# Altium schematic sheet 05_GAP9_CHIP_GPIO.SchDoc
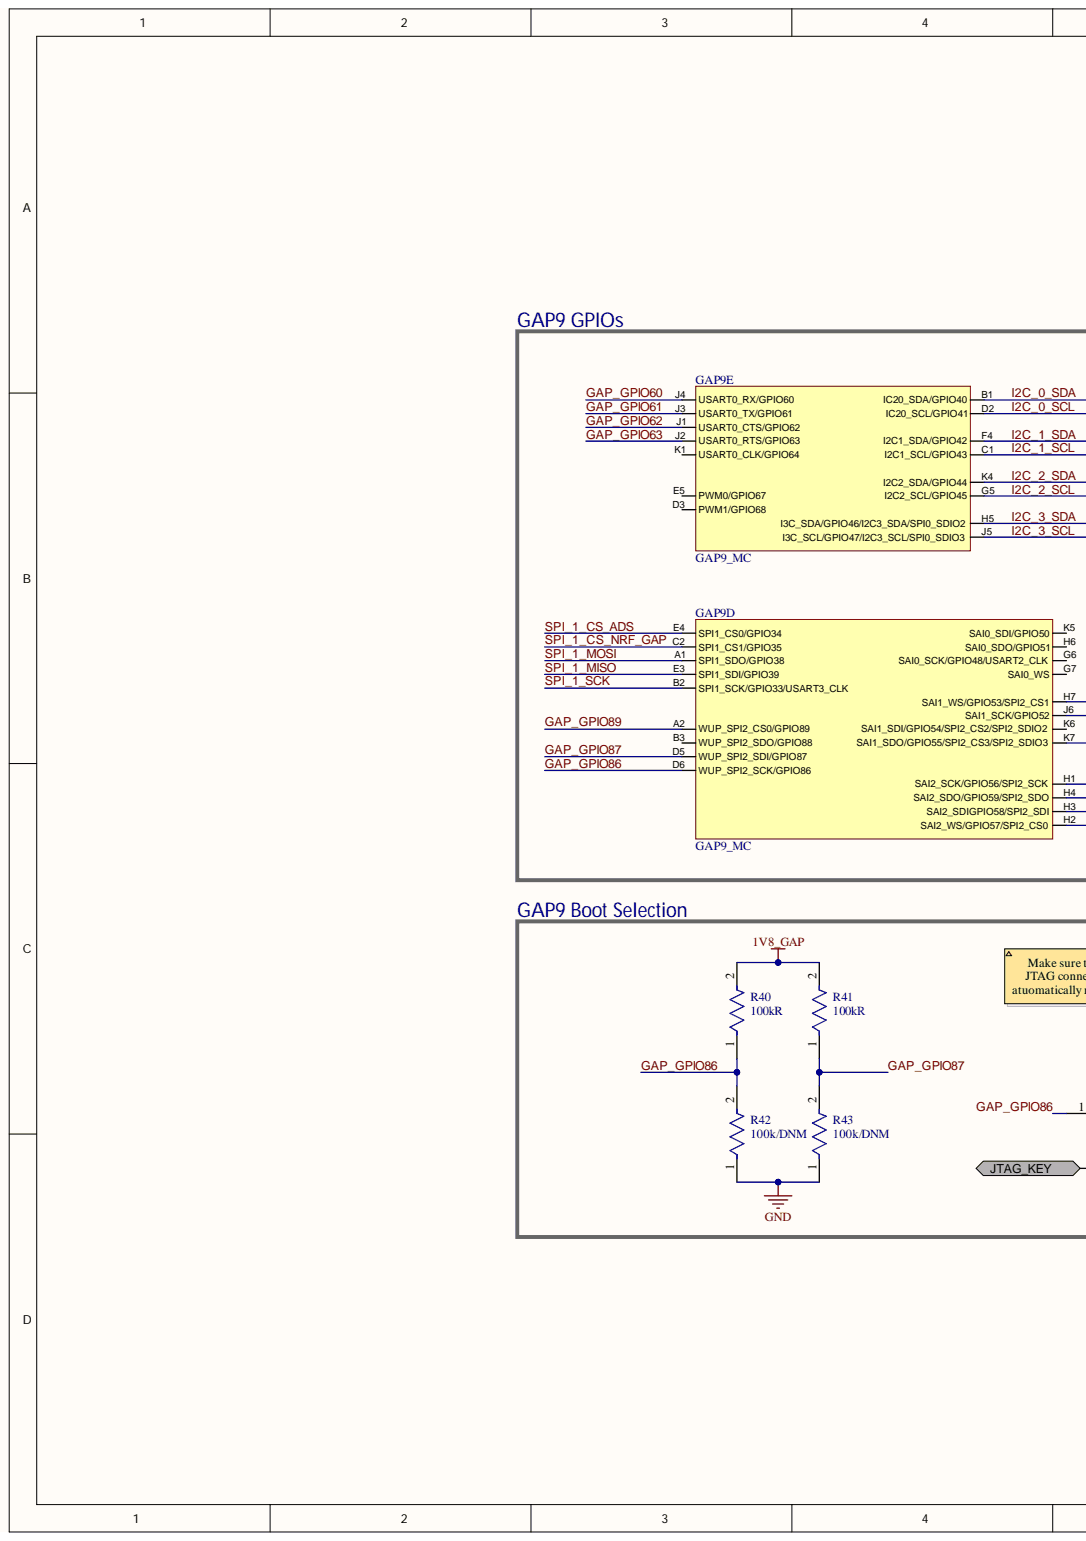
[diagram p0: 05_GAP9_CHIP_GPIO.SchDoc, left]
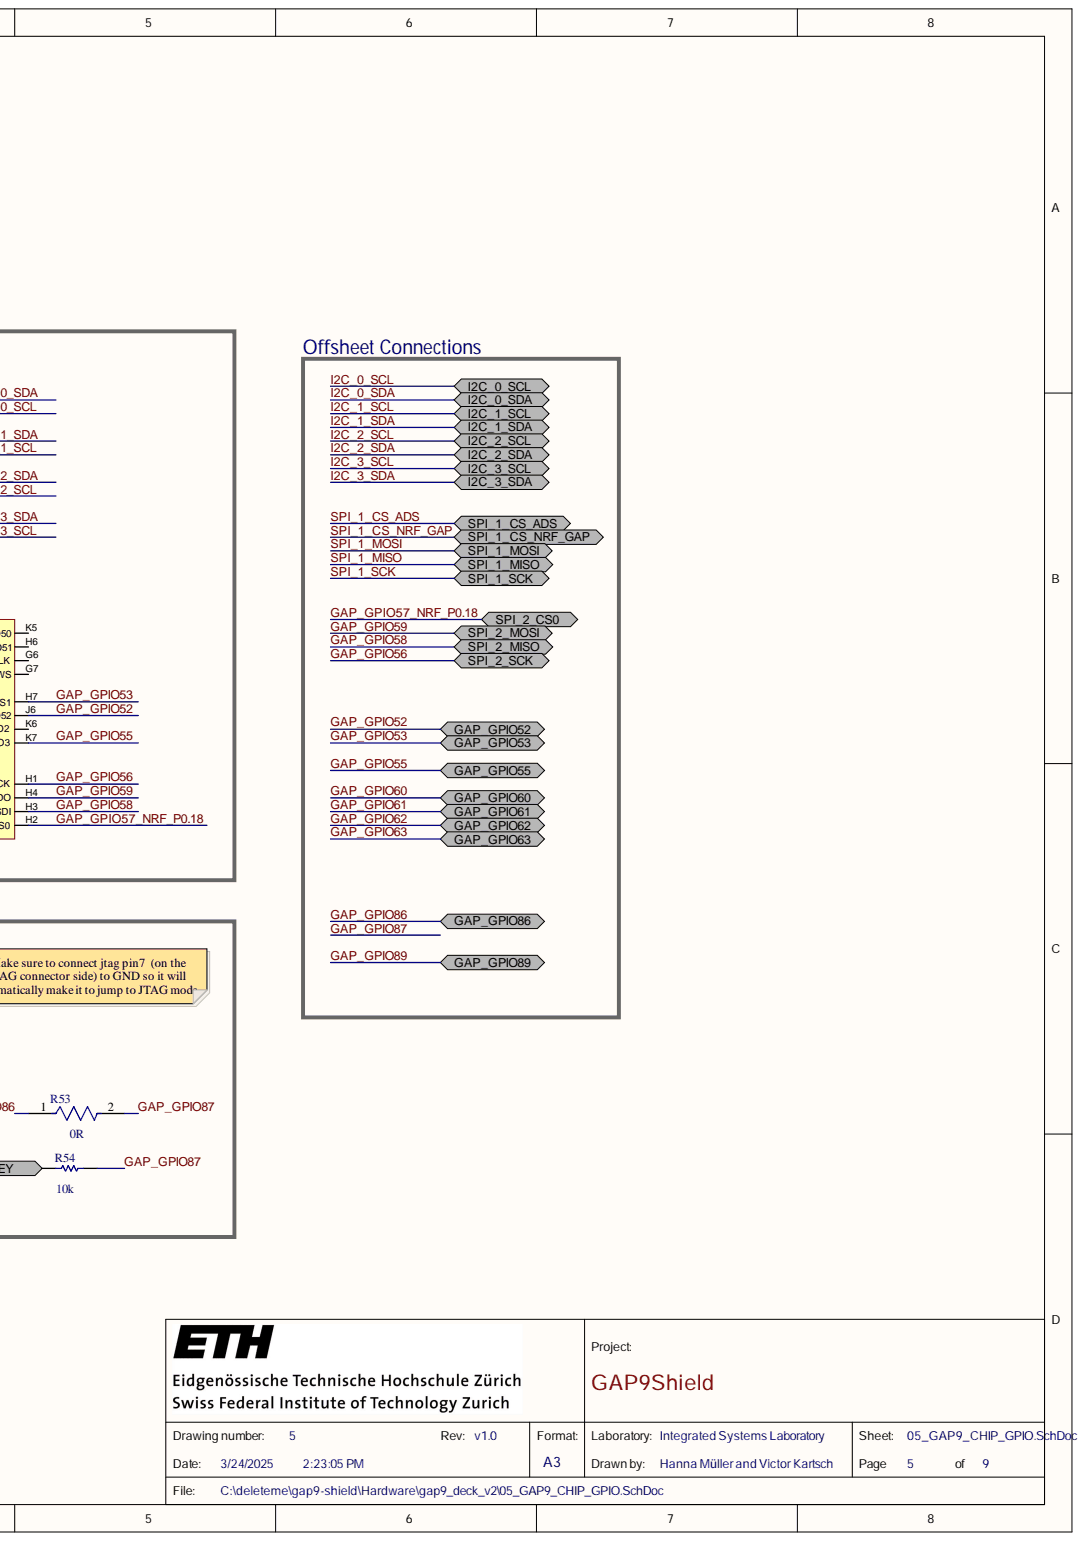
[diagram p1: 05_GAP9_CHIP_GPIO.SchDoc, right]

## Parts
GAP9  GAP9_MC  [GAP9]  (parts D,E)
R40  value 100kR  Resistance: 100kR  [FP-ERJ1GN-IPC_A]  (hidden comment: ERJ-1GNF1003C)
R41  value 100kR  Resistance: 100kR  [FP-ERJ1GN-IPC_A]  (hidden comment: ERJ-1GNF1003C)
R42  value 100k/DNM  Resistance: 100k/DNM  [FP-ERJ1GN-IPC_A]  (hidden comment: DNM)
R43  value 100k/DNM  Resistance: 100k/DNM  [FP-ERJ1GN-IPC_A]  (hidden comment: DNM)
R53  value 0R  Resistance: 0R  [FP-ERJ1GN-MFG]  (hidden comment: ERJ-1GN0R00C)
R54  value 10k  [RESC0603X28X15LL05T05]  (hidden comment: ERJ-U01F1001C)

## Ports
GAP_GPIO52, GAP_GPIO53, GAP_GPIO55, GAP_GPIO60, GAP_GPIO61, GAP_GPIO62, GAP_GPIO63, GAP_GPIO86, GAP_GPIO89, I2C_0_SCL, I2C_0_SDA, I2C_1_SCL, I2C_1_SDA, I2C_2_SCL, I2C_2_SDA, I2C_3_SCL, I2C_3_SDA, JTAG_KEY, SPI_1_CS_ADS, SPI_1_CS_NRF_GAP, SPI_1_MISO, SPI_1_MOSI, SPI_1_SCK, SPI_2_CS0, SPI_2_MISO, SPI_2_MOSI, SPI_2_SCK

## Nets
1V8_GAP: R40.2 R41.2
GND: R42.1 R43.1
GAP_GPIO52: GAP9.J6(SAI1_SCK/GPIO52) ; port GAP_GPIO52
GAP_GPIO53: GAP9.H7(SAI1_WS/GPIO53/SPI2_CS1) ; port GAP_GPIO53
GAP_GPIO55: GAP9.K7(SAI1_SDO/GPIO55/SPI2_CS3/SPI2_SDIO3) ; port GAP_GPIO55
GAP_GPIO56: GAP9.H1(SAI2_SCK/GPIO56/SPI2_SCK) ; port SPI_2_SCK
GAP_GPIO57_NRF_P0.18: GAP9.H2(SAI2_WS/GPIO57/SPI2_CS0) ; port SPI_2_CS0
GAP_GPIO58: GAP9.H3(SAI2_SDIGPIO58/SPI2_SDI) ; port SPI_2_MISO
GAP_GPIO59: GAP9.H4(SAI2_SDO/GPIO59/SPI2_SDO) ; port SPI_2_MOSI
GAP_GPIO60: GAP9.J4(USART0_RX/GPIO60) ; port GAP_GPIO60
GAP_GPIO61: GAP9.J3(USART0_TX/GPIO61) ; port GAP_GPIO61
GAP_GPIO62: GAP9.J1(USART0_CTS/GPIO62) ; port GAP_GPIO62
GAP_GPIO63: GAP9.J2(USART0_RTS/GPIO63) ; port GAP_GPIO63
GAP_GPIO86: GAP9.D6(WUP_SPI2_SCK/GPIO86) R40.1 R42.2 R53.1 ; port GAP_GPIO86
GAP_GPIO87: GAP9.D5(WUP_SPI2_SDI/GPIO87) R41.1 R43.2 R53.2 R54.2
GAP_GPIO89: GAP9.A2(WUP_SPI2_CS0/GPIO89) ; port GAP_GPIO89
I2C_0_SCL: GAP9.D2(IC20_SCL/GPIO41) ; port I2C_0_SCL
I2C_0_SDA: GAP9.B1(IC20_SDA/GPIO40) ; port I2C_0_SDA
I2C_1_SCL: GAP9.C1(I2C1_SCL/GPIO43) ; port I2C_1_SCL
I2C_1_SDA: GAP9.F4(I2C1_SDA/GPIO42) ; port I2C_1_SDA
I2C_2_SCL: GAP9.G5(I2C2_SCL/GPIO45) ; port I2C_2_SCL
I2C_2_SDA: GAP9.K4(I2C2_SDA/GPIO44) ; port I2C_2_SDA
I2C_3_SCL: GAP9.J5(I3C_SCL/GPIO47/I2C3_SCL/SPI0_SDIO3) ; port I2C_3_SCL
I2C_3_SDA: GAP9.H5(I3C_SDA/GPIO46/I2C3_SDA/SPI0_SDIO2) ; port I2C_3_SDA
JTAG_KEY: R54.1 ; port JTAG_KEY
SPI_1_CS_ADS: GAP9.E4(SPI1_CS0/GPIO34) ; port SPI_1_CS_ADS
SPI_1_CS_NRF_GAP: GAP9.C2(SPI1_CS1/GPIO35) ; port SPI_1_CS_NRF_GAP
SPI_1_MISO: GAP9.E3(SPI1_SDI/GPIO39) ; port SPI_1_MISO
SPI_1_MOSI: GAP9.A1(SPI1_SDO/GPIO38) ; port SPI_1_MOSI
SPI_1_SCK: GAP9.B2(SPI1_SCK/GPIO33/USART3_CLK) ; port SPI_1_SCK

Not connected: GAP9 B3(WUP_SPI2_SDO/GPIO88) D3(PWM1/GPIO68) E5(PWM0/GPIO67) G6(SAI0_SCK/GPIO48/USART2_CLK) G7(SAI0_WS) H6(SAI0_SDO/GPIO51) K1(USART0_CLK/GPIO64) K5(SAI0_SDI/GPIO50) K6(SAI1_SDI/GPIO54/SPI2_CS2/SPI2_SDIO2)

## Library data of the parts
R40-R43 = CMP-2004-03446-2: RES SMD 100K OHM 1% 1/20W 0201; Max Power Dissipation: 50mW; Temperature Coefficient: 200ppm/°C; Voltage Rating: 25V; Case Code (Imperial): 0201; Case Code (Metric): 0603; Case/Package: 0201; Component Kind: Standard; Composition: Thick Film; Contact Plating: Tin; Height: 0.01inch; Lead Free: Lead Free; Length: 0.024inch; Library Name: Altium Content Vault; Max Operating Temperature: 125°C; Min Operating Temperature: -55°C; Mount: Surface Mount; Number of Pins: 2; Number of Terminations: 2; Package Quantity: 15000; Packaging: Tape and Reel; Power Rating: 50mW; Radiation Hardening: No; REACH SVHC: No SVHC; Termination: SMD/SMT; Tolerance: 1%; Voltage Rating (DC): 25V; Weight: 6E-06oz; Width: 0.012inch
R53 = CMP-1010-00001-3: RES SMD 0 OHM JUMPER 1/20W 0201; Max Power Dissipation: 50mW; Temperature Coefficient: 600ppm/°C; Tolerance: 1%; Voltage Rating: 25V; Case Code (Imperial): 0201; Case Code (Metric): 0603; Case/Package: 0201; Component Kind: Standard; Construction: Rectangular; Contact Plating: Tin; Depth: 0.3mm; Features: Automotive AEC-Q200; Height: 0.23mm; Lead Free: Lead Free; Length: 0.6mm; Library Name: Altium Content Vault; Max Operating Temperature: 125°C; Military Standard: Not; Min Operating Temperature: -55°C; Mount: Surface Mount; Number of Terminations: 2; Package Quantity: 15000; Packaging: Tape and Reel; Power Rating: 50mW; Resistor Type: Thick Film; RoHS Compliant: Yes; Series: ERJ; Voltage Rating (DC): 25V; Width: 0.3mm
R54 = CMP-2004-00016-1: Manufacturer: Vishay Dale; Part Number: CRCW020110K0FNED; Component Kind: Standard; Library Name: Altium Content Vault
GAP9 = GAP9_MC: Component Kind: Standard; Library Name: EEG_SchLib.SchLib
